# Altium schematic sheet SENSOR_Flash_RAM.SchDoc(SENSOR_Flash_RAM)
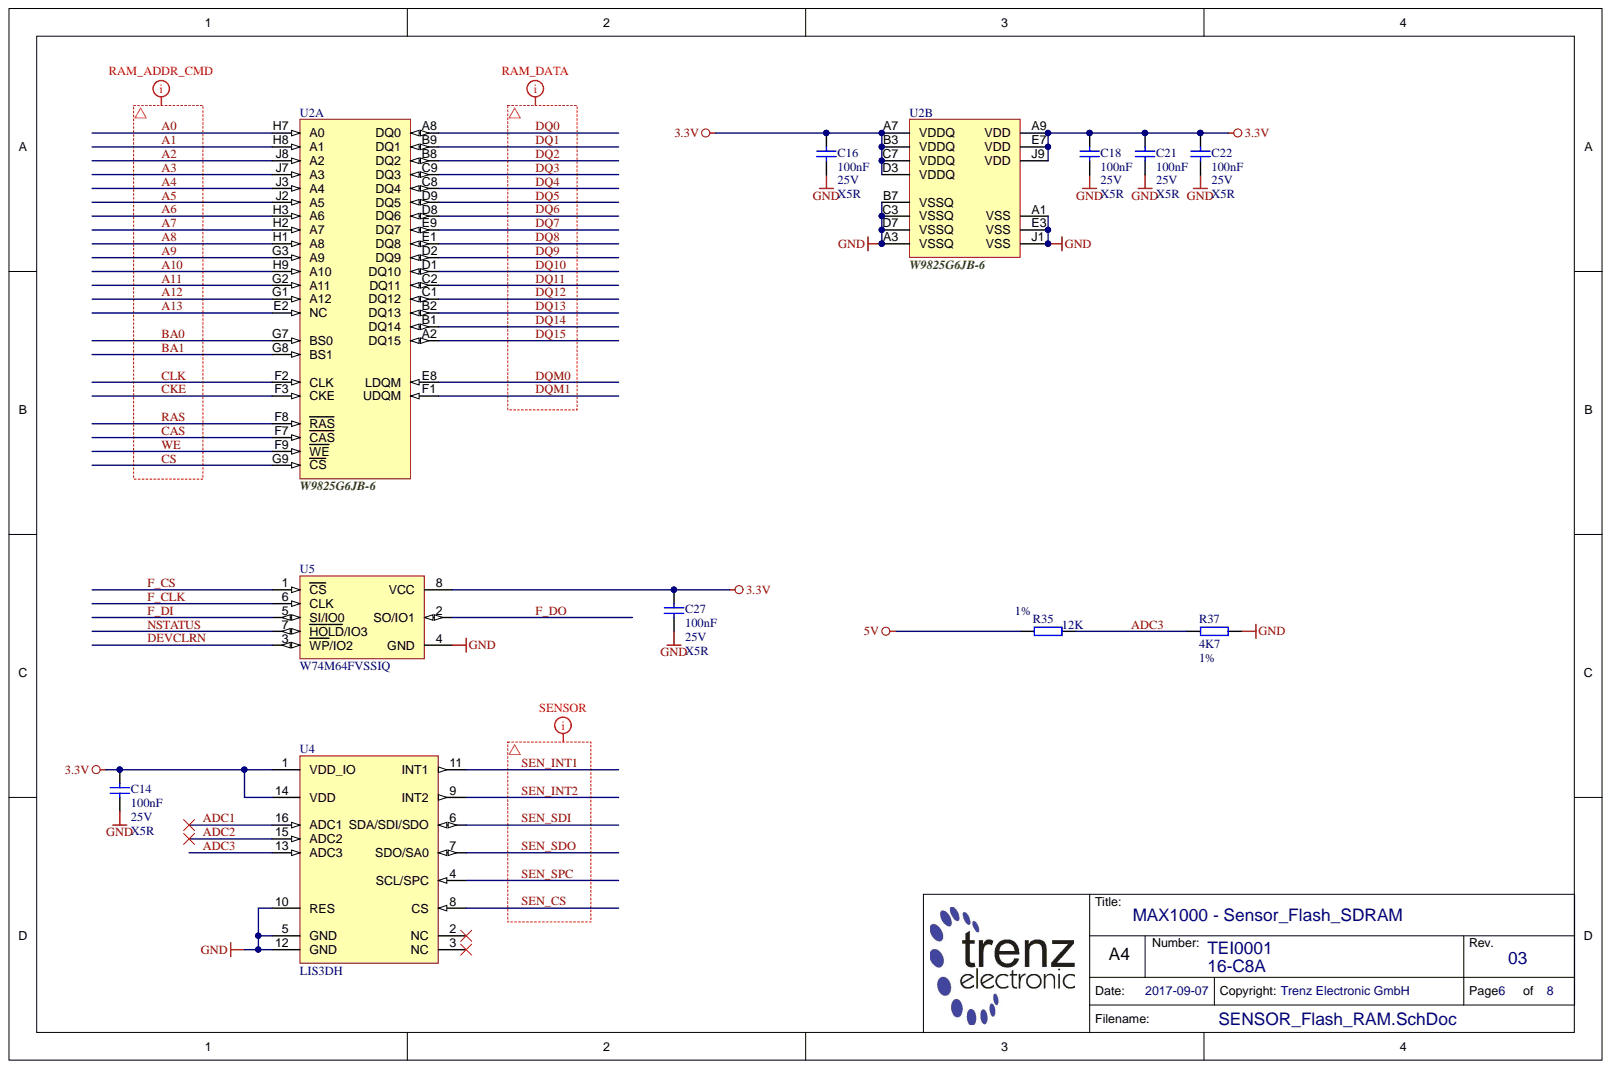
[diagram p0: SENSOR_Flash_RAM.SchDoc]

## Parts
C14  100nF  Technology: X5R  Voltage: 25V  [CAPC1005X55N]
C16  100nF  Technology: X5R  Voltage: 25V  [CAPC1005X55N]
C18  100nF  Technology: X5R  Voltage: 25V  [CAPC1005X55N]
C21  100nF  Technology: X5R  Voltage: 25V  [CAPC1005X55N]
C22  100nF  Technology: X5R  Voltage: 25V  [CAPC1005X55N]
C27  100nF  Technology: X5R  Voltage: 25V  [CAPC1005X55N]
R35  12K  Tolerance: 1%  [RESC1005X40N]
R37  4K7  Tolerance: 1%  [RESC1005X40N]
U2  W9864G6JT-6  [BGA54C80P9X9_800X800X120]  (value not drawn)  (parts A,B)
U2  W9825G6JB-6  [BGA54C80P9X9_800X800X120]  (part A)
U4  LIS3DH  [PQFN50P300X300X100-16N]
U5  W74M64FVSSIQ  [SOIC127P790X216-8N]

## Nets
3.3V: C14.1 C16.1 C18.1 C21.1 C22.1 C27.1 U2.A7 U2.A9 U2.B3 U2.C7 U2.D3 U2.E7 U2.J9 U4.1(VDD_IO) U4.14(VDD) U5.8(VCC)
5V: R35.1
GND: C14.2 C16.2 C18.2 C21.2 C22.2 C27.2 R37.2 U2.A1 U2.A3 U2.B7 U2.C3 U2.D7 U2.E3 U2.J1 U4.5(GND) U4.10(RES) U4.12(GND) U5.4(GND)
A0: U2.H7
A1: U2.H8
A2: U2.J8
A3: U2.J7
A4: U2.J3
A5: U2.J2
A6: U2.H3
A7: U2.H2
A8: U2.H1
A9: U2.G3
A10: U2.H9
A11: U2.G2
A12: U2.G1
A13: U2.E2
ADC1: U4.16(ADC1)
ADC2: U4.15(ADC2)
ADC3: R35.2 R37.1 U4.13(ADC3)
BA0: U2.G7
BA1: U2.G8
CAS: U2.F7
CKE: U2.F3
CLK: U2.F2
CS: U2.G9
DEVCLRN: U5.3(WP/IO2)
DQ0: U2.A8
DQ1: U2.B9
DQ2: U2.B8
DQ3: U2.C9
DQ4: U2.C8
DQ5: U2.D9
DQ6: U2.D8
DQ7: U2.E9
DQ8: U2.E1
DQ9: U2.D2
DQ10: U2.D1
DQ11: U2.C2
DQ12: U2.C1
DQ13: U2.B2
DQ14: U2.B1
DQ15: U2.A2
DQM0: U2.E8
DQM1: U2.F1
F_CLK: U5.6(CLK)
F_CS: U5.1(CS)
F_DI: U5.5(SI/IO0)
F_DO: U5.2(SO/IO1)
NSTATUS: U5.7(HOLD/IO3)
RAS: U2.F8
SEN_CS: U4.8(CS)
SEN_INT1: U4.11(INT1)
SEN_INT2: U4.9(INT2)
SEN_SDI: U4.6(SDA/SDI/SDO)
SEN_SDO: U4.7(SDO/SA0)
SEN_SPC: U4.4(SCL/SPC)
WE: U2.F9
N00329:
N00330:
N00331:

Not connected: U4 2(NC) 3(NC)

## Library data of the parts
C14 C16 C18 C21 C22 C27 = 22472: KON 100nF 25V X5R SMD ±10%; Database Table Name: C_1005_0402; Mixed: SMT; Preferred: Yes; Value: 100n; AN: 22472; Case: 1005 (0402); Component Kind: Standard; Library Name: TE.DbLib
R35 = 22598: WID SMD 12K 1% 0,063W; Database Table Name: R_1005_0402; Mixed: SMT; Preferred: Yes; AN: 22598; Case: 1005 (0402); Component Kind: Standard; Library Name: TE.DbLib; Technology: TK100; Value: 12K
R37 = 22585: WID SMD 4K7 1% 0,063W; Database Table Name: R_1005_0402; Mixed: SMT; Preferred: Yes; Value: 4.7K; AN: 22585; Case: 1005 (0402); Component Kind: Standard; Library Name: TE.DbLib; Technology: TK100
U2 = 28045: Database Table Name: SRAM; Mixed: SMT; AN: 28045; Case: TFBGA54; Component Kind: Standard; Description: W9864G6JT-6; H-ART: W9864G6JT-6; Library Name: TE.DbLib; HelpURL: b:\AD\adlib\datasheet\W9864G6JT-6\
U2 = 28740: Database Table Name: SRAM; Mixed: SMT; AN: 28740; Case: TFBGA54; Component Kind: Standard; Description: W9825G6JB-6; H-ART: W9825G6JB-6; Library Name: TE.DbLib; HelpURL: b:\AD\adlib\datasheet\W9825G6JB\
U4 = 27979: Database Table Name: SENSOR; Mixed: SMT; AN: 27979; Case: LGA16; Component Kind: Standard; Description: LIS3DH; H-ART: LIS3DH; Library Name: TE.DbLib; HelpURL: b:\AD\adlib\datasheet\ST_LIS3DH\
U5 = 28247: Database Table Name: FLASH; Mixed: SMT; AN: 28247; Case: SO8W; Component Kind: Standard; Description: W74M64FVSSIQ; H-ART: W74M64FVSSIQ; Library Name: TE.DbLib; HelpURL: B:\ad\adlib\datasheet\W74M64FV
